# Altium schematic sheet Alimentation.SchDoc
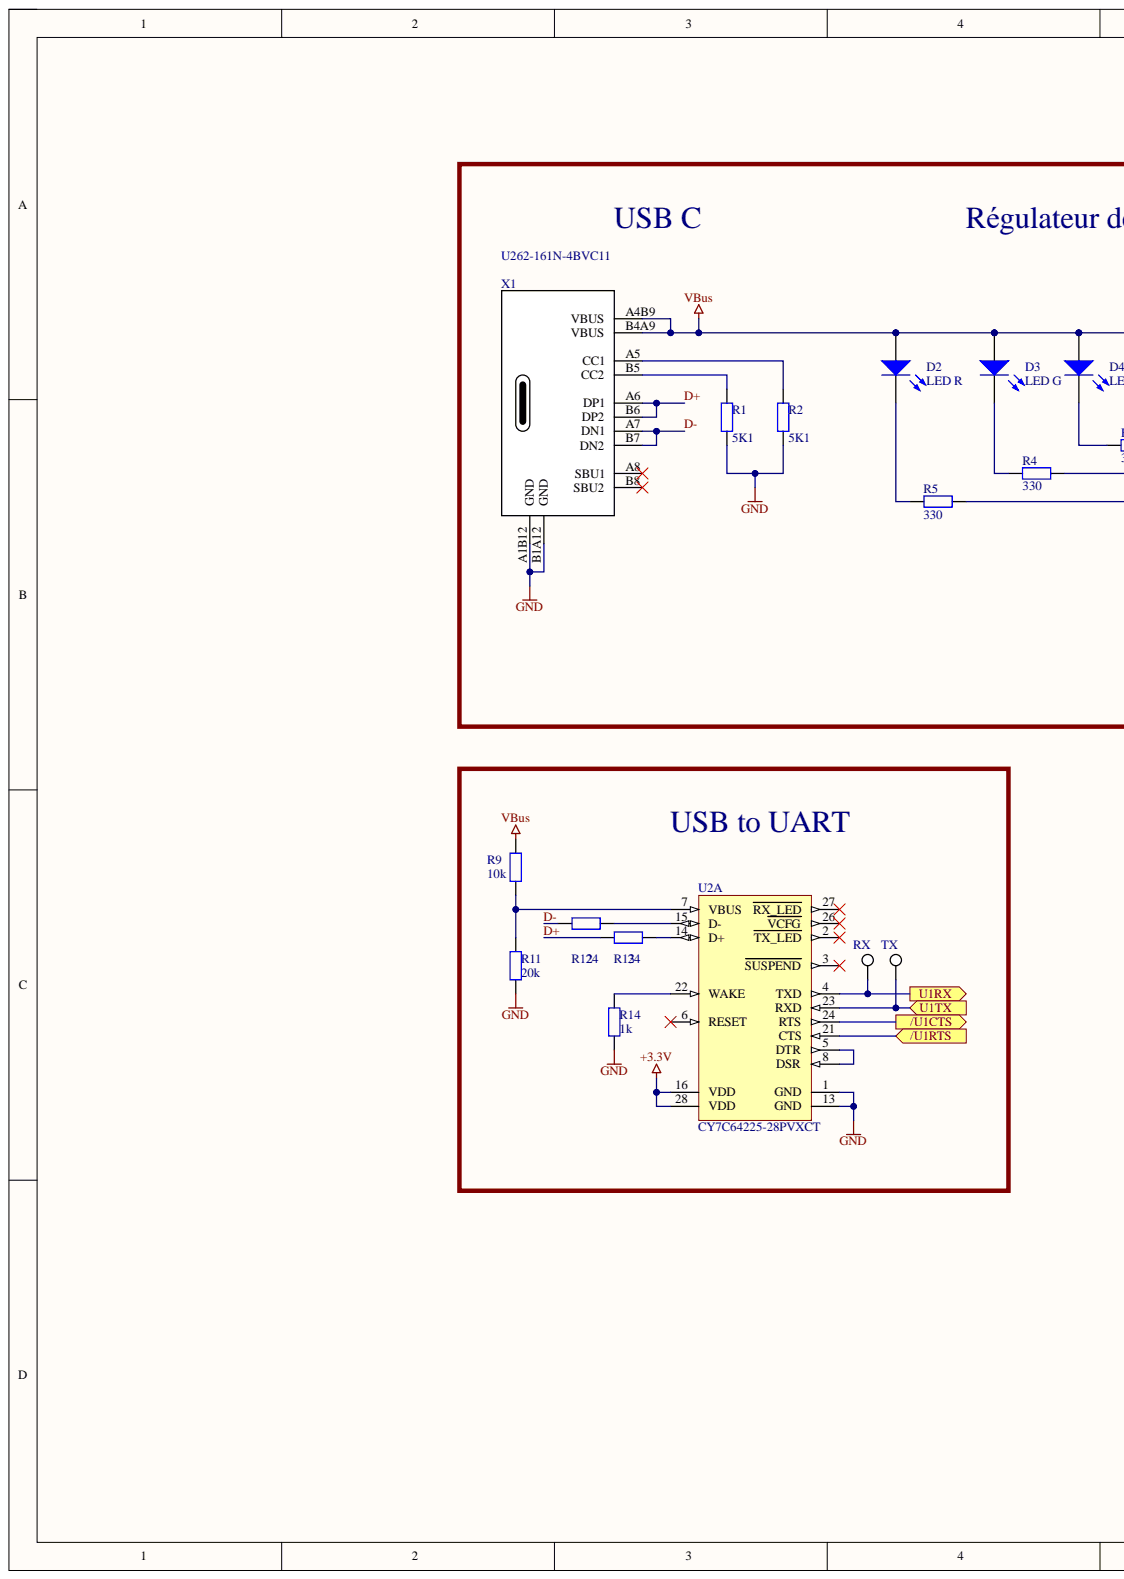
[diagram p0: Alimentation.SchDoc, left]
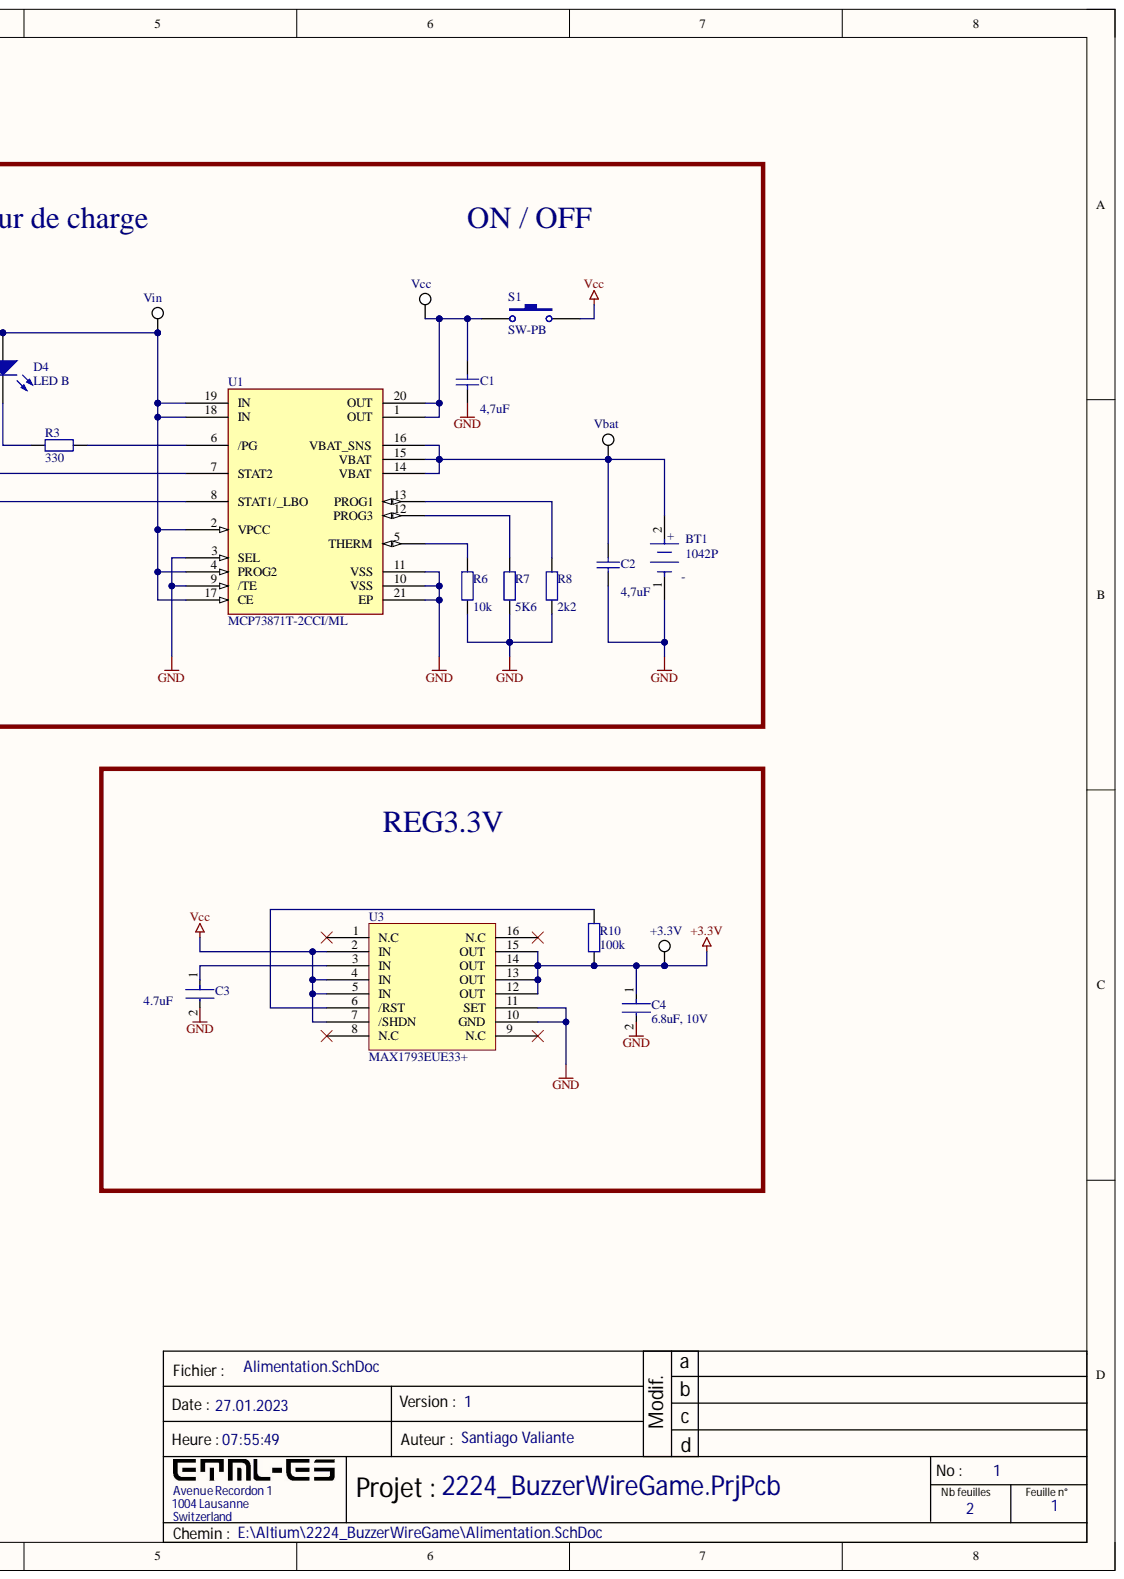
[diagram p1: Alimentation.SchDoc, right]

## Parts
+3.3V  3.3V  [KSTN-5019_V]
BT1  1042P  [FP-1042P-MFG]
C1  value 4,7uF  [RAD-0.3]  (hidden comment: Cap)
C2  value 4,7uF  [RAD-0.3]  (hidden comment: Cap)
C3  Capacitance: 4.7uF  [CAPC2012M]  (hidden comment: 4.7uF, 35V)
C4  6.8uF, 10V  [CAPC2012M]
D2  LED R  [3.2X1.6X1.1]
D3  LED G  [3.2X1.6X1.1]
D4  LED B  [3.2X1.6X1.1]
R1  value 5K1  [AXIAL-0.4]  (hidden comment: Res2)
R2  value 5K1  [AXIAL-0.4]  (hidden comment: Res2)
R3  value 330  [AXIAL-0.4]  (hidden comment: Res2)
R4  value 330  [AXIAL-0.4]  (hidden comment: Res2)
R5  value 330  [AXIAL-0.4]  (hidden comment: Res2)
R6  value 10k  [AXIAL-0.4]  (hidden comment: Res2)
R7  value 5K6  [AXIAL-0.4]  (hidden comment: Res2)
R8  value 2k2  [AXIAL-0.4]  (hidden comment: Res2)
R9  value 10k  [AXIAL-0.4]  (hidden comment: Res2)
R10  100k  [RESC2012M]
R11  value 20k  [AXIAL-0.4]  (hidden comment: Res2)
R12  Res2  [AXIAL-0.4]  (value not drawn)
R13  value 24  [AXIAL-0.4]  (hidden comment: Res2)
R14  value 1k  [AXIAL-0.4]  (hidden comment: Res2)
RX  Vbat  [KSTN-5019_V]  (value not drawn)
S1  SW-PB  [SPST-2]
TX  Vbat  [KSTN-5019_V]  (value not drawn)
U1  MCP73871T-2CCI/ML  [Microchip_C04V2]
U2  CY7C64225-28PVXCT  [CYP-PVX28_M]  (part A)
U3  MAX1793EUE33+  [TSOP65P640X110_HS-17N]
Vbat  Vbat  [KSTN-5019_V]
Vcc  Vbat  [KSTN-5019_V]  (value not drawn)
Vin  Vbat  [KSTN-5019_V]  (value not drawn)
X1  U262-161N-4BVC11

## Ports
/U1CTS, /U1RTS, U1RX, U1TX

## Nets
+3.3V: +3.3V.1 C4.1 R10.1 U2.16(VDD) U2.28(VDD) U3.12(OUT) U3.13(OUT) U3.14(OUT) U3.15(OUT)
GND: BT1.1 C1.1 C2.1 C3.2 C4.2 R1.1 R2.1 R6.1 R7.1 R8.1 R11.1 R14.1 U1.3(SEL) U1.9(/TE) U1.10(VSS) U1.11(VSS) U1.21(EP) U2.1(GND) U2.13(GND) U3.10(GND) U3.11(SET) X1.A1B12(GND) X1.B1A12(GND)
VBus: D2.1 D3.1 D4.1 R9.2 U1.2(VPCC) U1.4(PROG2) U1.17(CE) U1.18(IN) U1.19(IN) Vin.1 X1.A4B9(VBUS) X1.B4A9(VBUS)
Vcc: S1.2 U3.2(IN) U3.4(IN) U3.5(IN) U3.7(/SHDN)
/U1CTS: U2.24(RTS) ; port /U1CTS
/U1RTS: U2.21(CTS) ; port /U1RTS
D+: R13.1 X1.A6(DP1) X1.B6(DP2)
D-: R12.1 X1.A7(DN1) X1.B7(DN2)
U1RX: RX.1 U2.4(TXD) ; port U1RX
U1TX: TX.1 U2.23(RXD) ; port U1TX
NetBT1_2: BT1.2 C2.2 U1.14(VBAT) U1.15(VBAT) U1.16(VBAT_SNS) Vbat.1
NetC1_2: C1.2 S1.1 U1.1(OUT) U1.20(OUT) Vcc.1
NetC3_1: C3.1 U3.3(IN)
NetD2_2: D2.2 R5.1
NetD3_2: D3.2 R4.2
NetD4_2: D4.2 R3.1
NetR1_2: R1.2 X1.B5(CC2)
NetR2_2: R2.2 X1.A5(CC1)
NetR3_2: R3.2 U1.6(/PG)
NetR4_1: R4.1 U1.7(STAT2)
NetR5_2: R5.2 U1.8(STAT1/_LBO)
NetR6_2: R6.2 U1.5(THERM)
NetR7_2: R7.2 U1.12(PROG3)
NetR8_2: R8.2 U1.13(PROG1)
NetR9_1: R9.1 R11.2 U2.7(VBUS)
NetR10_2: R10.2 U3.6(/RST)
NetR12_2: R12.2 U2.15(D-)
NetR13_2: R13.2 U2.14(D+)
NetR14_2: R14.2 U2.22(WAKE)
NetU2_5: U2.5(DTR) U2.8(DSR)

Not connected: U2 2(TX_LED) 3(SUSPEND) 6(RESET) 26(VCFG) 27(RX_LED); U3 1(N.C) 8(N.C) 9(N.C) 16(N.C); X1 A8(SBU1) B8(SBU2)

## Library data of the parts
+3.3V RX TX Vbat Vcc Vin = CMP-1672-00008-1: Test Point, 1 Position SMD, RoHS, Tape and Reel; Component Kind: Standard; ComponentLink1Description: Manufacturer URL; ComponentLink1URL: http://www.keyelco.com/; ComponentLink2Description: Datasheet; ComponentLink2URL: http://www.keyelco.com/product-pdf.cfm?p=1357; Contact Plating: Silver; DatasheetVersion: 03/2014; Library Name: Altium Content Vault; Mount: Surface Mount; Mounting Technology: Surface Mount; Package Quantity: 1000; PackageDescription: 1-Pin Surface Mount Device, Body 3.81 x 2.03 mm; PackageReference: 5019; Packaging: Tape and Reel; Pins: 1; RoHSCompliant: True
BT1 = CMP-19636-000019-1: BATTERY HOLDER 18650 SMD TAB; Number of Cells: 1; Component Kind: Standard; Lead Free: Lead Free; Library Name: Altium Content Vault; Max Operating Temperature: 145°C; Min Operating Temperature: -50°C; Mount: Surface Mount; Package Quantity: 200; Packaging: Tray; Termination: SMD/SMT
C1 C2 = Cap: Capacitor; Component Kind: Standard; LatestRevisionDate: 17-Jul-2002; LatestRevisionNote: Re-released for DXP Platform.; Library Name: Miscellaneous Devices.IntLib; PackageDescription: Radial Cap, Thru-Hole; 2 Leads; 0.3 in Pin Spacing; PackageReference: RAD-0.3; Published: 8-Jun-2000; Signal Integrity: Cap; Simulation: CAP; Publisher: Altium Limited
C3 = CMP-08246-002735-1: Multilayer Ceramic Capacitors 4.7μF ±10% 35V X7R SMD 0805; Case Code (Imperial): 0805; Case Code (Metric): 2012; Case/Package: 0805; Component Kind: Standard; Construction: Flat; Depth: 1.25mm; Dielectric: X7R; Dielectric Material: Ceramic; Height: 1.25mm; Lead Free: Lead Free; Length: 2mm; Max Operating Temperature: 125°C; Min Operating Temperature: -55°C; Mount: Surface Mount; Package Quantity: 2000; Packaging: Tape and Reel; Radiation Hardening: No; REACH SVHC: No SVHC; RoHS Compliant: Yes; Series: C; Termination: SMD/SMT; Thickness: 0.057inch; Tolerance: 10%; Voltage Rating: 35V; Voltage Rating (DC): 35V; Weight: 0.000194oz; Width: 0.049inch; Library Name: 2121_Robot3roues_V2.0.SCHLIB
C4 = CMP-08246-000118-1: Cap Ceramic 6.8uF 10V X5R 20% Pad SMD 0805 +85°C Automotive T/R; Capacitance: 6.8uF; Case Code (Imperial): 0805; Case Code (Metric): 2012; Case/Package: 0805; Component Kind: Standard; Dielectric: X5R; Height: 1.25mm; Length: 0.079inch; Max Operating Temperature: 85°C; Min Operating Temperature: -55°C; Mount: Surface Mount; Package Quantity: 2000; Packaging: Tape and Reel; Ratings: AEC-Q200; Thickness: 0.057inch; Tolerance: 20%; Voltage Rating: 10V; Voltage Rating (DC): 10V; Weight: 0.000194oz; Width: 0.049inch; Library Name: 2121_Robot3roues_V2.0.SCHLIB
D2-D4 = LED2: Typical RED, GREEN, YELLOW, AMBER GaAs LED; Component Kind: Standard; LatestRevisionDate: 17-Jul-2002; LatestRevisionNote: Re-released for DXP Platform.; Library Name: Miscellaneous Devices.IntLib; PackageDescription: SM LED; 2 Flat Leads; PackageReference: 3.2X1.6X1.1; PackageVersion: Feb-2002; Published: 8-Jun-2000; Simulation: LED2; Publisher: Altium Limited
R1-R9 R11 R13 R14 = Res2: Resistor; Component Kind: Standard; LatestRevisionDate: 17-Jul-2002; LatestRevisionNote: Re-released for DXP Platform.; Library Name: Miscellaneous Devices.IntLib; PackageDescription: Axial Device, Thru-Hole; 2 Leads; 0.4 in Pin Spacing; PackageReference: AXIAL-0.4; Published: 8-Jun-2000; Signal Integrity: Res; Simulation: RESISTOR; Publisher: Altium Limited
R10 = CMP-2001-00479-1: Manufacturer: Panasonic; Part Number: ERJ-P06J104V; Component Kind: Standard; Library Name: 2121_Robot3roues_V2.0.SCHLIB
R12 = Res2: Resistor; Value: 24; Component Kind: Standard; LatestRevisionDate: 17-Jul-2002; LatestRevisionNote: Re-released for DXP Platform.; Library Name: Miscellaneous Devices.IntLib; PackageDescription: Axial Device, Thru-Hole; 2 Leads; 0.4 in Pin Spacing; PackageReference: AXIAL-0.4; Published: 8-Jun-2000; Signal Integrity: Res; Simulation: RESISTOR; Publisher: Altium Limited
S1 = SW-PB: Switch; Component Kind: Standard; LatestRevisionDate: 17-Jul-2002; LatestRevisionNote: Re-released for DXP Platform.; Library Name: Miscellaneous Devices.IntLib; PackageDescription: Switch; 2 Leads; PackageReference: SPST-2; Published: 24-Mar-1999; Publisher: Altium Limited
U1 = MCP73871T-2CCI/ML: Charger IC Lithium Ion/Polymer 20-QFN _4x4_; MF: Microchip; Component Kind: Standard; Digi-Key_Part_Number: MCP73871T-2CCI/MLCT-ND; Digi-Key_Purchase_URL: https://www.digikey.com/product-detail/en/microchip-technology/MCP73871T-2CCI-ML/MCP73871T-2CCI-MLCT-ND/7065594?utm_source=snapeda&utm_medium=aggregator&utm_campaign=symbol; MP: MCP73871T-2CCI/ML; Package: QFN-20 Microchip; SnapEDA_Link: https://www.snapeda.com/parts/MCP73871T-2CCI/ML/Microchip/view-part/1049920/?ref=snap; Library Name: 2121_Robot3roues.SCHLIB
U2 = CMP-0967-00141-1: USB-to-UART Bridge Controller, 3 to 5.25 V, 0 to 70 degC, 28-Pin SSOP (PVX28), Tape and Reel; Manufacturer: Cypress; Max. Operating Voltage (V): 5.25; Memory Architecture: Flash; Min. Operating Voltage (V): 3; Component Kind: Standard; ComponentLink1Description: Manufacturer URL; ComponentLink1URL: http://www.cypress.com/; ComponentLink2Description: Datasheet; ComponentLink2URL: http://www.cypress.com/?docID=48153; ComponentLink3Description: Package Specification; ComponentLink3URL: http://www.cypress.com/?docID=1371; DatasheetVersion: Rev. *D; Library Name: Altium Content Vault; Max. Operating Temp. (degreesC): 70; Min. Operating Temp. (degreesC): 0; Mounting Technology: Surface Mount; PackageDescription: 28-Pin Super Small Outline Package, Body 10.2 x 5.3 mm, Pitch 0.65 mm; PackageReference: PVX28; PackageVersion: Rev. *C, 10/2001; Packing: Tape and Reel; PartNumber: CY7C64225-28PVXCT; RoHS: Pb-Free
X1 = USB-C Type 2.0: U262-161N-4BVC11   XKB Connectivity; Component Kind: Standard; ComponentLink1Description: LCSC  C319148; ComponentLink1URL: https://lcsc.com/product-detail/USB-Connectors_XKB-Connectivity-U262-161N-4BVC11_C319148.html; Library Name: Schlib1.SchLib; Supplier 1: LCSC; Supplier Part Number 1: C319148
U3 = MAX1793EUE33+: Component Kind: Standard; Library Name: Robot3Roue.SchLib
